# Altium schematic sheet CITest_Board_V1.0.SchDoc(CITest_Board_V1.0)
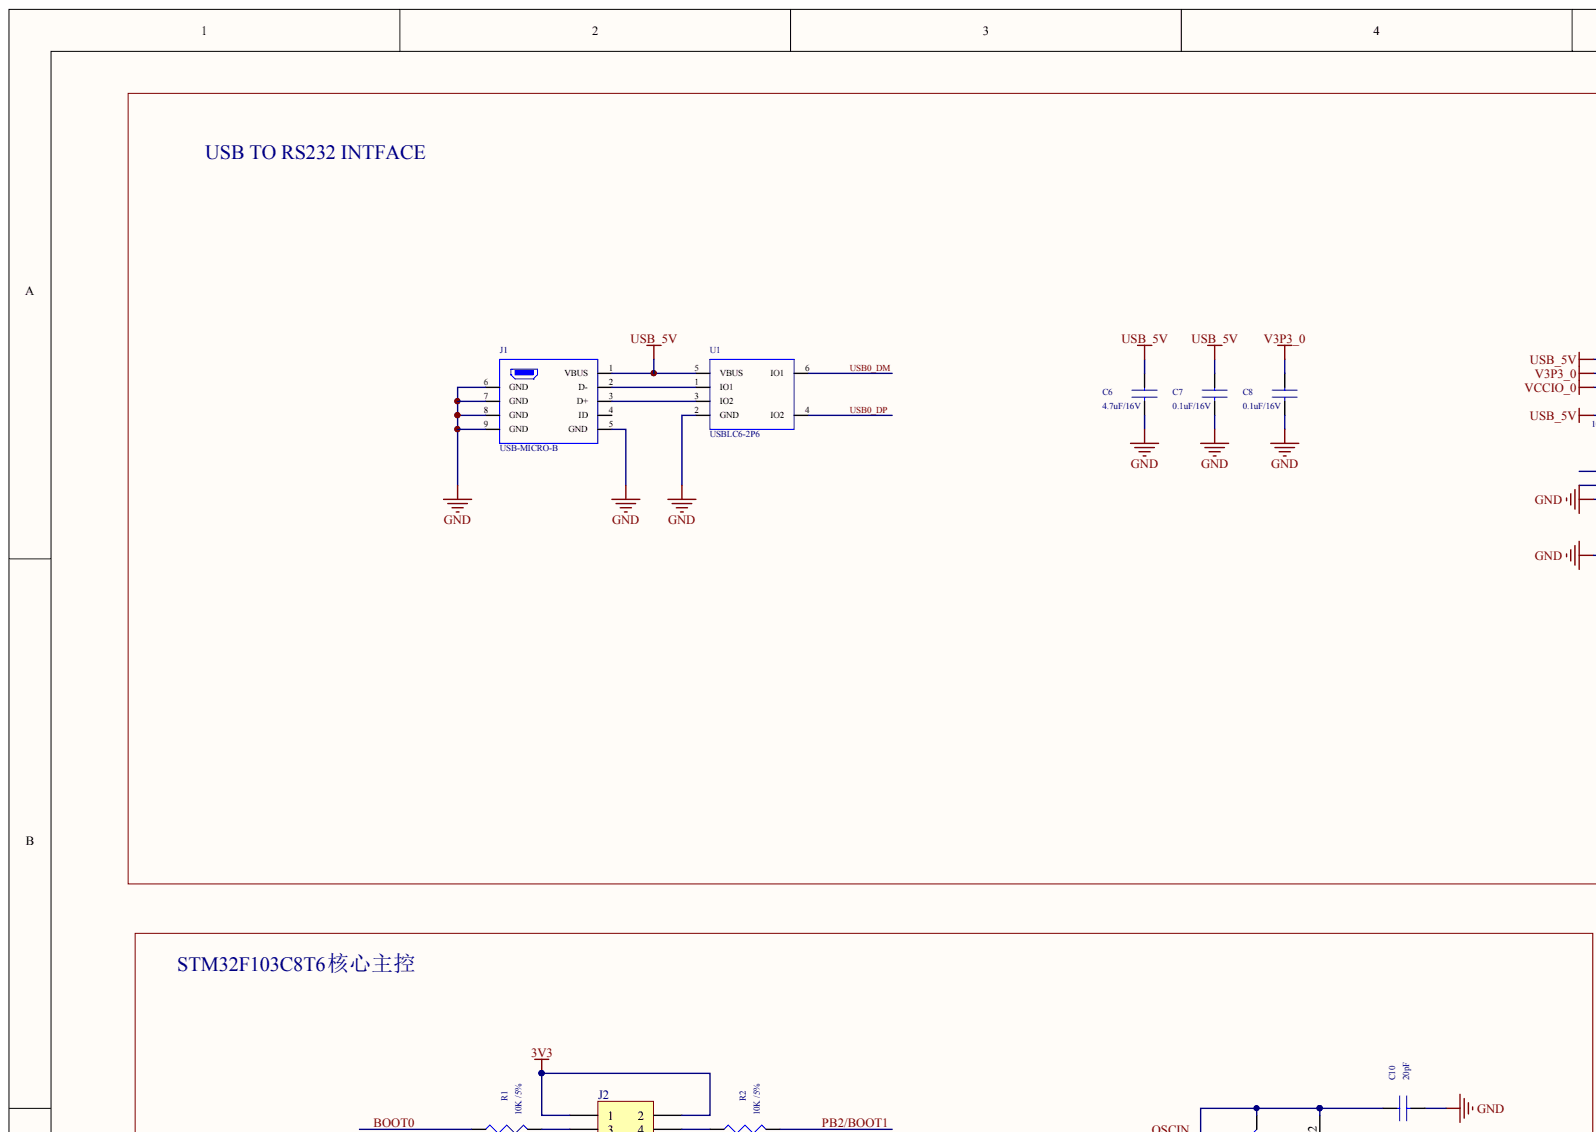
[diagram p0: CITest_Board_V1.0.SchDoc, top left]
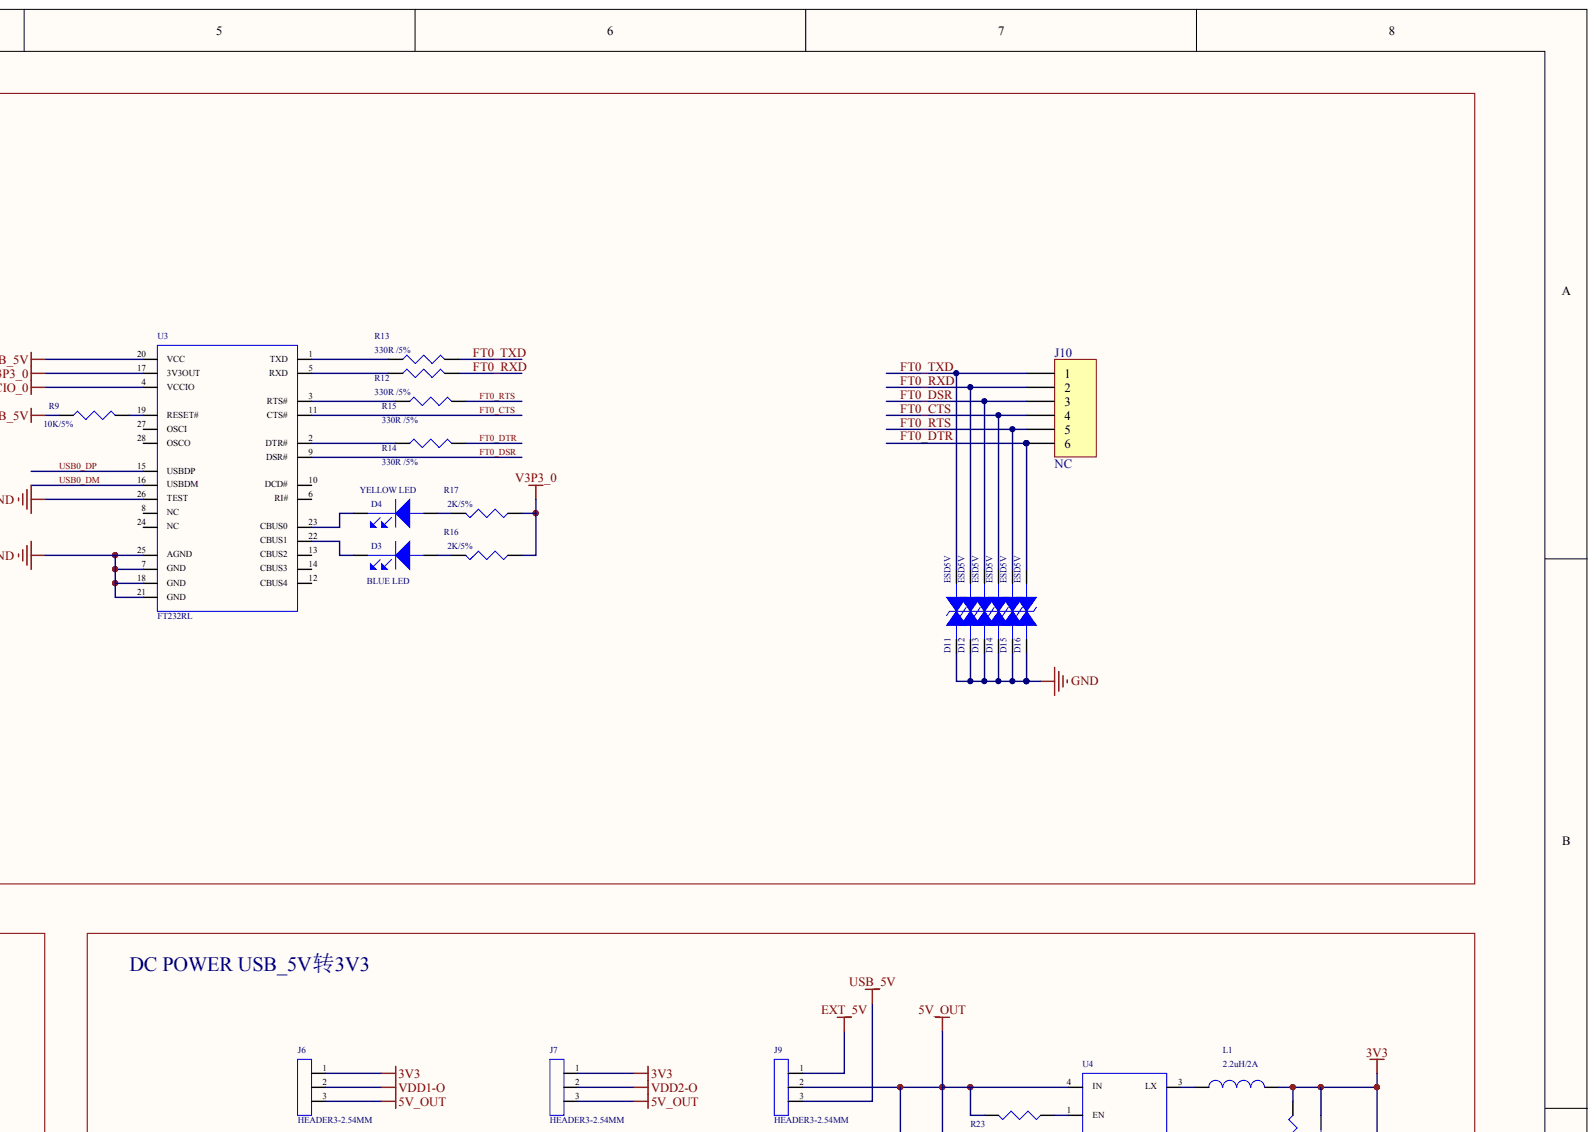
[diagram p1: CITest_Board_V1.0.SchDoc, top right]
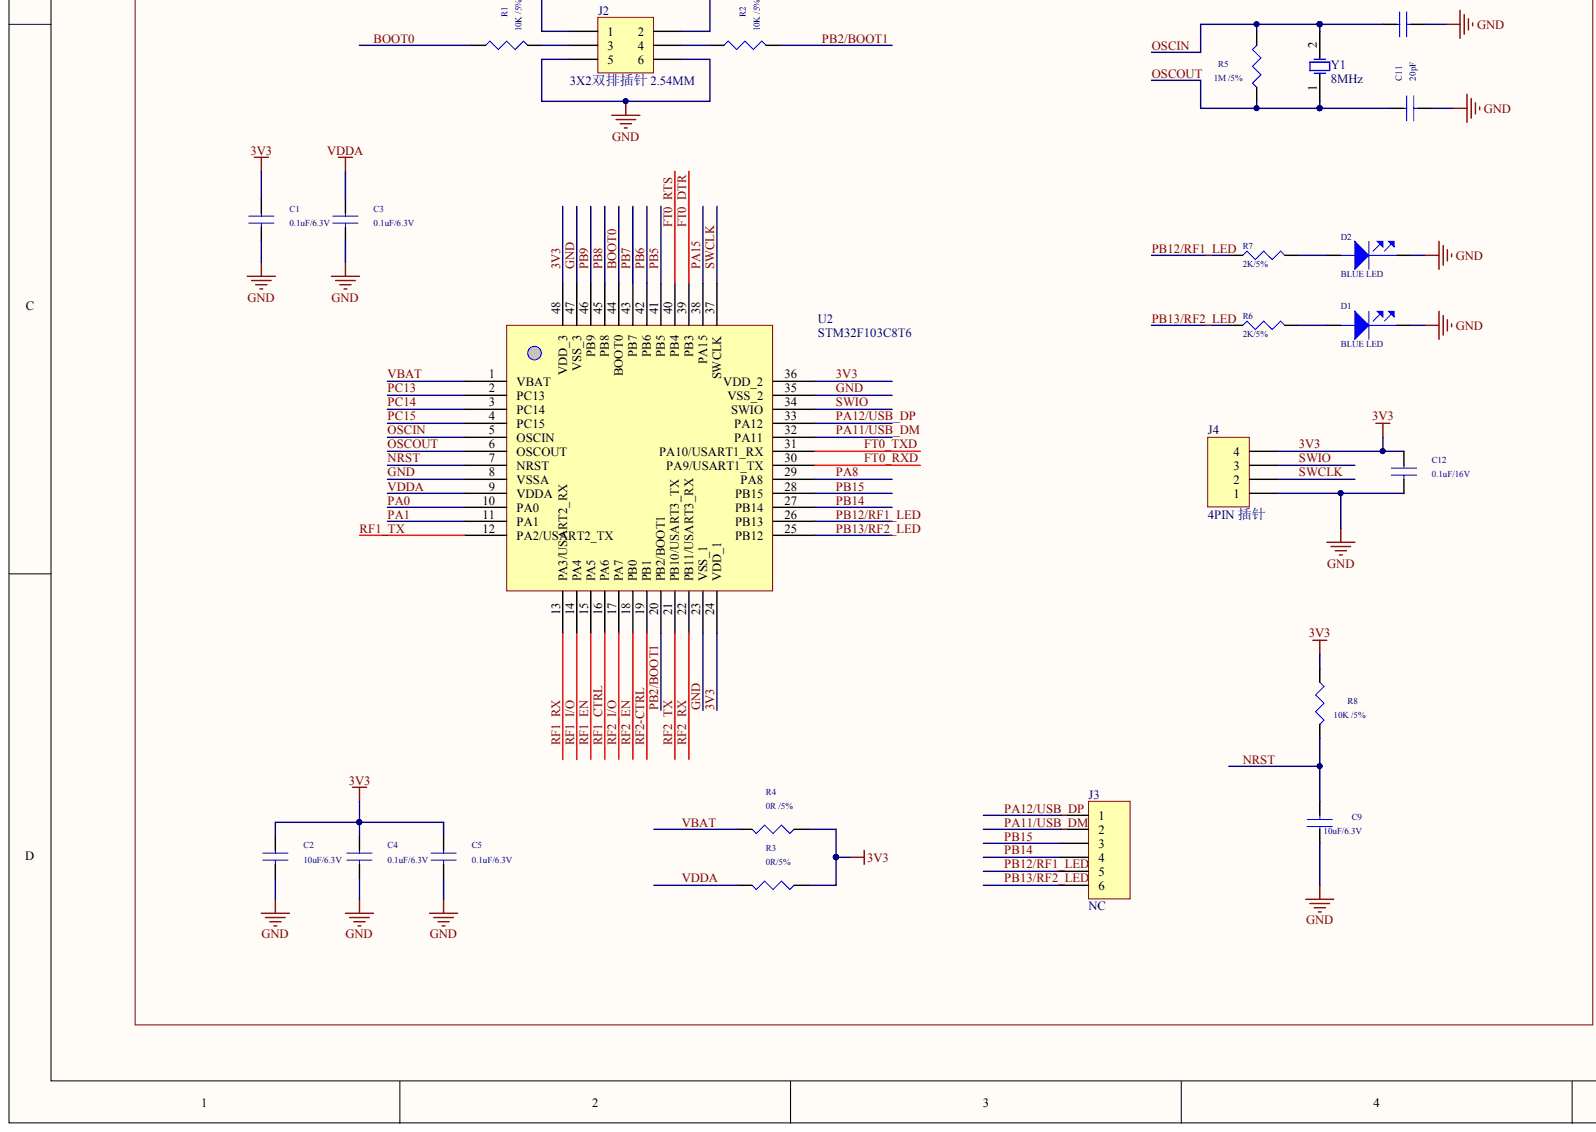
[diagram p2: CITest_Board_V1.0.SchDoc, bottom left]
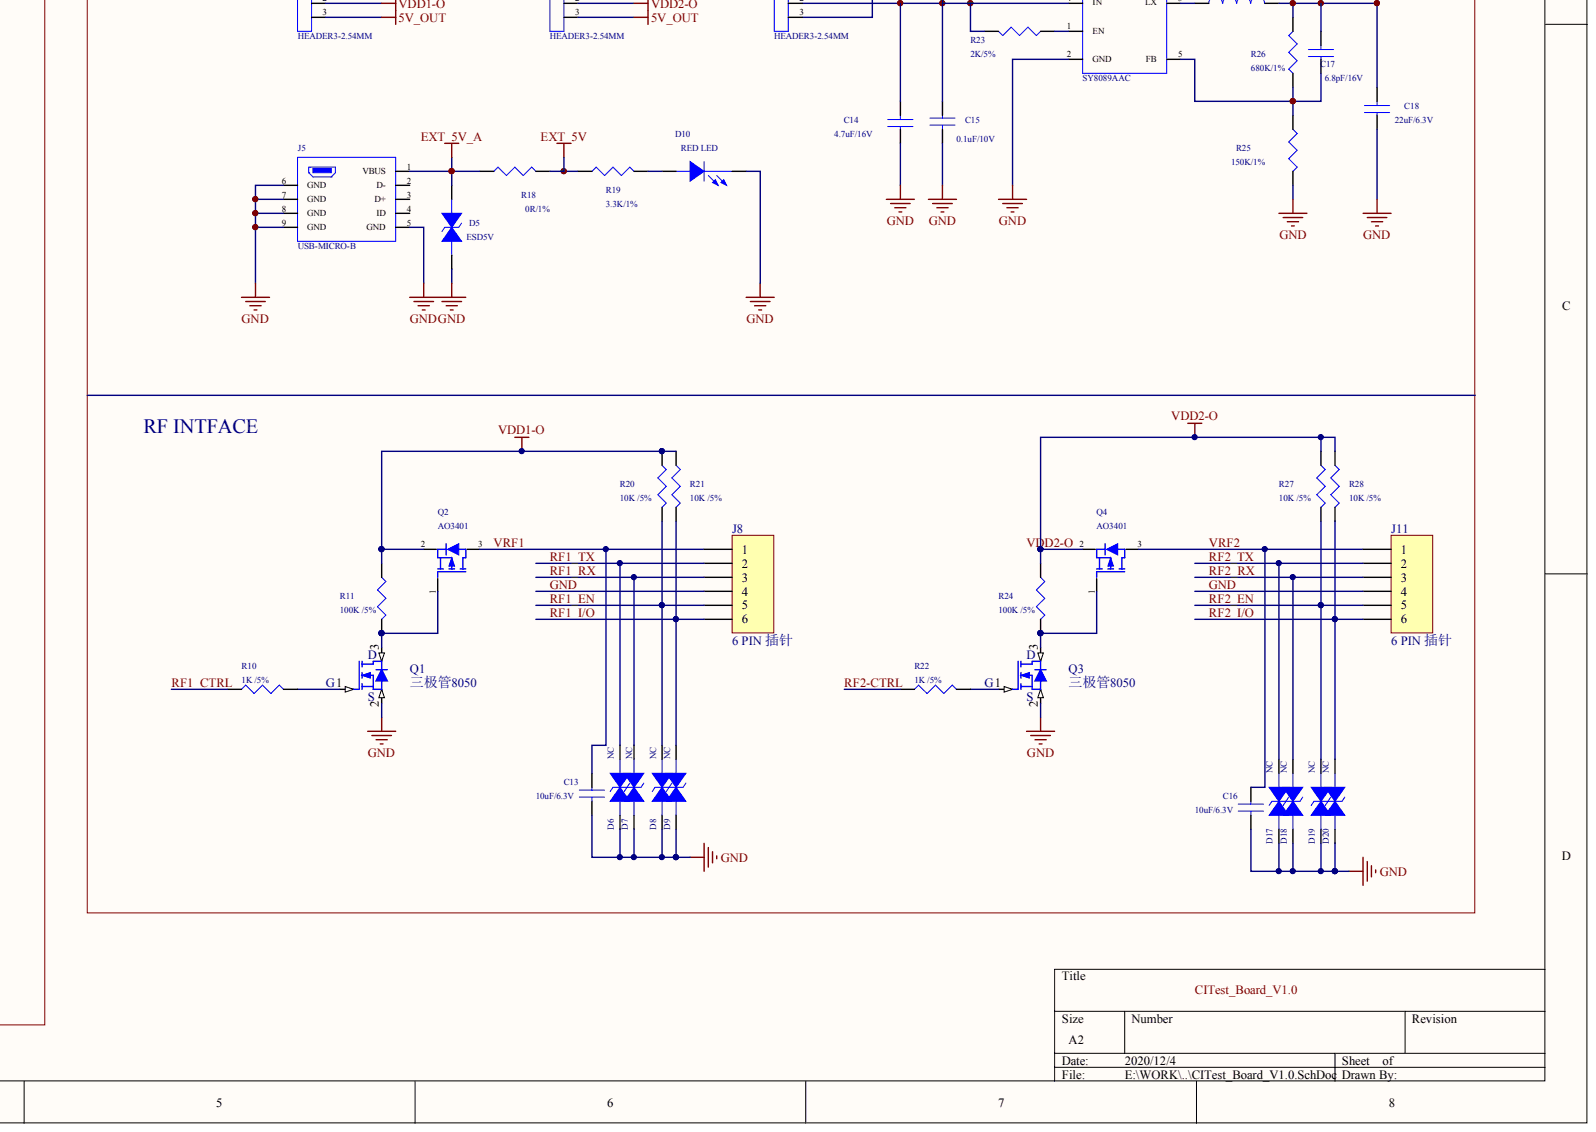
[diagram p3: CITest_Board_V1.0.SchDoc, bottom right]

## Parts
C1  0.1uF/6.3V
C2  10uF/6.3V
C3  0.1uF/6.3V
C4  0.1uF/6.3V
C5  0.1uF/6.3V
C6  4.7uF/16V
C7  0.1uF/16V
C8  0.1uF/16V
C9  10uF/6.3V
C10  20pF
C11  20pF
C12  0.1uF/16V
C13  10uF/6.3V
C14  4.7uF/16V
C15  0.1uF/10V
C16  10uF/6.3V
C17  6.8pF/16V
C18  22uF/6.3V
D1  BLUE LED
D2  BLUE LED
D3  BLUE LED
D4  YELLOW LED
D5  ESD5V
D6  NC
D7  NC
D8  NC
D9  NC
D10  RED LED
D11  ESD5V
D12  ESD5V
D13  ESD5V
D14  ESD5V
D15  ESD5V
D16  ESD5V
D17  NC
D18  NC
D19  NC
D20  NC
J1  USB-MICRO-B
J2  3X2双排插针 2.54MM
J3  NC
J4  4PIN 插针
J5  USB-MICRO-B
J6  value HEADER3-2.54MM  (hidden comment: CON3_0)
J7  value HEADER3-2.54MM  (hidden comment: CON3_0)
J8  6 PIN 插针
J9  value HEADER3-2.54MM  (hidden comment: CON3_0)
J10  NC
J11  6 PIN 插针
L1  2.2uH/2A
Q1  三极管8050
Q2  AO3401
Q3  三极管8050
Q4  AO3401
R1  10K /5%
R2  10K /5%
R3  0R/5%
R4  0R /5%
R5  1M /5%
R6  2K/5%
R7  2K/5%
R8  10K /5%
R9  10K/5%
R10  1K /5%
R11  100K /5%
R12  330R /5%
R13  330R /5%
R14  330R /5%
R15  330R /5%
R16  2K/5%
R17  2K/5%
R18  0R/1%
R19  3.3K/1%
R20  10K /5%
R21  10K /5%
R22  1K /5%
R23  2K/5%
R24  100K /5%
R25  150K/1%
R26  680K/1%
R27  10K /5%
R28  10K /5%
U1  USBLC6-2P6
U2  STM32F103C8T6
U3  FT232RL
U4  SY8089AAC
Y1  8MHz

## Nets
5V_OUT: C14.2 C15.1 J6.3 J7.3 J9.2 R23.1 U4.4(IN)
EXT_5V: J9.1 R18.2 R19.2
EXT_5V_A: D5.2 J5.1(VBUS) R18.1
USB_5V: C6.1 C7.1 J1.1(VBUS) J9.3 R9.1 U1.5(VBUS) U3.20(VCC)
V3P3_0: C8.1 R16.1 R17.1 U3.17(3V3OUT)
VCCIO_0: U3.4(VCCIO)
VDD1-O: J6.2 Q2.2 R11.2 R20.2 R21.2
3V3: C1.1 C2.1 C4.1 C5.1 C12.1 C17.2 C18.2 J2.1 J2.2 J4.4 J6.1 J7.1 L1.1 R3.2 R4.2 R8.2 R26.1 U2.24(VDD_1) U2.36(VDD_2) U2.48(VDD_3)
BOOT0: R1.2 U2.44(BOOT0)
FT0_CTS: D14.2 J10.4 U3.11(CTS#)
FT0_DSR: D13.2 J10.3 U3.9(DSR#)
FT0_DTR: D16.2 J10.6 R14.1 U2.39(PB3)
FT0_RTS: D15.2 J10.5 R15.1 U2.40(PB4)
FT0_RXD: D12.2 J10.2 R12.1 U2.30(PA9/USART1_TX)
FT0_TXD: D11.2 J10.1 R13.1 U2.31(PA10/USART1_RX)
GND: C1.2 C2.2 C3.2 C4.2 C5.2 C6.2 C7.2 C8.2 C9.1 C10.2 C11.2 C12.2 C13.2 C14.1 C15.2 C16.2 C18.1 D1.2 D2.2 D5.1 D6.1 D7.1 D8.1 D9.1 D10.2 D11.1 D12.1 D13.1 D14.1 D15.1 D16.1 D17.1 D18.1 D19.1 D20.1 J1.5(GND) J1.6(GND) J1.7(GND) J1.8(GND) J1.9(GND) J2.5 J2.6 J4.1 J5.5(GND) J5.6(GND) J5.7(GND) J5.8(GND) J5.9(GND) J8.4 J11.4 Q1.2 Q3.2 R25.1 U1.2(GND) U2.8(VSSA) U2.23(VSS_1) U2.35(VSS_2) U2.47(VSS_3) U3.7(GND) U3.18(GND) U3.21(GND) U3.25(AGND) U3.26(TEST) U4.2(GND)
NRST: C9.2 R8.1 U2.7(NRST)
OSCIN: C10.1 R5.2 U2.5(OSCIN) Y1.2
OSCOUT: C11.1 R5.1 U2.6(OSCOUT) Y1.1
PA0: U2.10(PA0)
PA1: U2.11(PA1)
PA8: U2.29(PA8)
PA11/USB_DM: J3.2 U2.32(PA11)
PA12/USB_DP: J3.1 U2.33(PA12)
PA15: U2.38(PA15)
PB2/BOOT1: R2.1 U2.20(PB2/BOOT1)
PB5: U2.41(PB5)
PB6: U2.42(PB6)
PB7: U2.43(PB7)
PB8: U2.45(PB8)
PB9: U2.46(PB9)
PB12/RF1_LED: J3.5 R7.1 U2.26(PB13)
PB13/RF2_LED: J3.6 R6.1 U2.25(PB12)
PB14: J3.4 U2.27(PB14)
PB15: J3.3 U2.28(PB15)
PC13: U2.2(PC13)
PC14: U2.3(PC14)
PC15: U2.4(PC15)
RF1_CTRL: R10.2 U2.16(PA6)
RF1_EN: D8.2 J8.5 R20.1 U2.15(PA5)
RF1_I/O: D9.2 J8.6 R21.1 U2.14(PA4)
RF1_RX: D7.2 J8.3 U2.13(PA3/USART2_RX)
RF1_TX: D6.2 J8.2 U2.12(PA2/USART2_TX)
RF2-CTRL: R22.2 U2.19(PB1)
RF2_EN: D19.2 J11.5 R27.1 U2.18(PB0)
RF2_I/O: D20.2 J11.6 R28.1 U2.17(PA7)
RF2_RX: D18.2 J11.3 U2.22(PB11/USART3_RX)
RF2_TX: D17.2 J11.2 U2.21(PB10/USART3_TX)
SWCLK: J4.2 U2.37(SWCLK)
SWIO: J4.3 U2.34(SWIO)
USB0_DM: U1.6(IO1) U3.16(USBDM)
USB0_DP: U1.4(IO2) U3.15(USBDP)
VBAT: R4.1 U2.1(VBAT)
VDD2-O: J7.2 Q4.2 R24.2 R27.2 R28.2
VDDA: C3.1 R3.1 U2.9(VDDA)
VRF1: C13.1 J8.1 Q2.3
VRF2: C16.1 J11.1 Q4.3
NetC17_1: C17.1 R25.2 R26.2 U4.5(FB)
NetD1_1: D1.1 R6.2
NetD2_1: D2.1 R7.2
NetD3_1: D3.1 R16.2
NetD3_2: D3.2 U3.22(CBUS1)
NetD4_1: D4.1 R17.2
NetD4_2: D4.2 U3.23(CBUS0)
NetD10_1: D10.1 R19.1
NetJ1_2: J1.2(D-) U1.1(IO1)
NetJ1_3: J1.3(D+) U1.3(IO2)
NetJ2_3: J2.3 R1.1
NetJ2_4: J2.4 R2.2
NetL1_2: L1.2 U4.3(LX)
NetQ1_1: Q1.1 R10.1
NetQ1_3: Q1.3 Q2.1 R11.1
NetQ3_1: Q3.1 R22.1
NetQ3_3: Q3.3 Q4.1 R24.1
NetR9_2: R9.2 U3.19(RESET#)
NetR12_2: R12.2 U3.5(RXD)
NetR13_2: R13.2 U3.1(TXD)
NetR14_2: R14.2 U3.2(DTR#)
NetR15_2: R15.2 U3.3(RTS#)
NetR23_2: R23.2 U4.1(EN)
CITEST_BOARD_V1.0:
N00050:

Not connected: J1 4(ID); J5 2(D-) 3(D+) 4(ID); U3 6(RI#) 8(NC) 10(DCD#) 12(CBUS4) 13(CBUS2) 14(CBUS3) 24(NC) 27(OSCI) 28(OSCO)

## Library data of the parts
C1-C5 C7-C13 C15-C17 = (no symbol name): PCB DECAL: C0402; Source_Path: C:\ORCAD\ORCAD_10.5\TOOLS\CAPTURE\LIBRARY\DISCRETE.OLB
  C1 C3-C5: Value: 0.1uF/6.3V
  C2 C9 C13 C16: Value: 10uF/6.3V
  C7 C8 C12: Value: 0.1uF/16V
  C10 C11: Value: 20pF
  C15: Value: 0.1uF/10V
  C17: Value: 6.8pF/16V
C6 C14 C18 = (no symbol name): PCB DECAL: C0603; Source_Path: C:\ORCAD\ORCAD_10.5\TOOLS\CAPTURE\LIBRARY\DISCRETE.OLB
  C6 C14: Value: 4.7uF/16V
  C18: Value: 22uF/6.3V
D1-D4 = (no symbol name): PCB DECAL: LED0603; Source_Path: D:\PROJECT\ESPRESSIF_PROJECT\#10444_ESP_FACTORY TEST BOARD FOR MODULE_V1.4_N_XX_20190408_V0.4\01_SCHEMATIC\ESP_FACTORY TEST BOARD FOR MODULE_V1.4_20190408.DSN
  D1-D3: Value: BLUE LED
  D4: Value: YELLOW LED
D5-D9 D11-D20 = (no symbol name): PCB DECAL: SOD-523; Source_Path: E:\PROJECT_NOW\ALMID03_LIBRARY.OLB
  D5 D11-D16: Value: ESD5V
  D6-D9 D17-D20: Value: NC
D10 = (no symbol name): PCB DECAL: LED0603; Source_Path: G:\WP\PROJECT\RF BOARD\RF TEST CHIP\WIFI_TESTCHIP_BOARD.DSN; Value: RED LED
J1 J5 = (no symbol name): PCB DECAL: USB-5P-MICROB; Source_Path: D:\YUXUFENG\ESPPROJECT\#30258-FACTORY_TESTBOARD\01_SCH\ESP_FACTORY_TESTBOARD.DSN; Value: USB-MICRO-B
J6 J7 J9 = (no symbol name): PCB DECAL: CON3-2P54; Source_Path: D:\YUXUFENG\ESPPROJECT\#30258-FACTORY_TESTBOARD\01_SCH\ESP_FACTORY_TESTBOARD.DSN
L1 = (no symbol name): PCB DECAL: LPS4018; Source_Path: C:\ORCAD\ORCAD_10.5\TOOLS\CAPTURE\LIBRARY\DISCRETE.OLB; Value: 2.2uH/2A
Q2 Q4 = (no symbol name): PCB DECAL: SOT-23-MOS; Comment_1: PMOS
R1-R17 R19-R28 = (no symbol name): PCB DECAL: R0402; Source_Path: E:\LI LELE\PROJ_LLL\NEW F BOARD\ESP8266 EVALUATION BOARD\ESP8266 EVALUATION BOARD.DSN
  R1 R2 R8 R20 R21 R27 R28: Value: 10K /5%
  R3: Value: 0R/5%
  R4: Value: 0R /5%
  R5: Value: 1M /5%
  R6 R7 R16 R17 R23: Value: 2K/5%
  R9: Value: 10K/5%
  R10 R22: Value: 1K /5%
  R11 R24: Value: 100K /5%
  R12-R15: Value: 330R /5%
  R19: Value: 3.3K/1%
  R25: Value: 150K/1%
  R26: Value: 680K/1%
R18 = (no symbol name): PCB DECAL: R0603; Source_Path: E:\LI LELE\PROJ_LLL\NEW F BOARD\ESP8266 EVALUATION BOARD\ESP8266 EVALUATION BOARD.DSN; Value: 0R/1%
U1 = (no symbol name): PCB DECAL: SOT-666; Source_Path: D:\YUXUFENG\ESPPROJECT\#30258-FACTORY_TESTBOARD\01_SCH\ESP_FACTORY_TESTBOARD.DSN; Value: USBLC6-2P6
U3 = (no symbol name): PCB DECAL: SSOP28-5P3; Source_Path: D:\YUXUFENG\ESPPROJECT\#30258-FACTORY_TESTBOARD\01_SCH\ESP_FACTORY_TESTBOARD.DSN; Value: FT232RL
U4 = (no symbol name): PCB DECAL: SOT-23-5; Source_Path: D:\YUXUFENG\ESPPROJECT\#30258-FACTORY_TESTBOARD\01_SCH\ESP_FACTORY_TESTBOARD.DSN; Value: SY8089AAC
J2-J4 J8 J10 J11 Q1 Q3 U2 Y1 = (no symbol name)
  J2-J4 J8 J10 J11: LatestRevisionDate: 17-Jul-2002; LatestRevisionNote: Re-released for DXP Platform.
  Y1: Published: 8-Jun-2000; LatestRevisionDate: 17-Jul-2002; LatestRevisionNote: Re-released for DXP Platform.
  J2-J4 J8 J10 J11 Y1: Publisher: Altium Limited
